# Altium schematic sheet AC-Power-Monitor.SchDoc
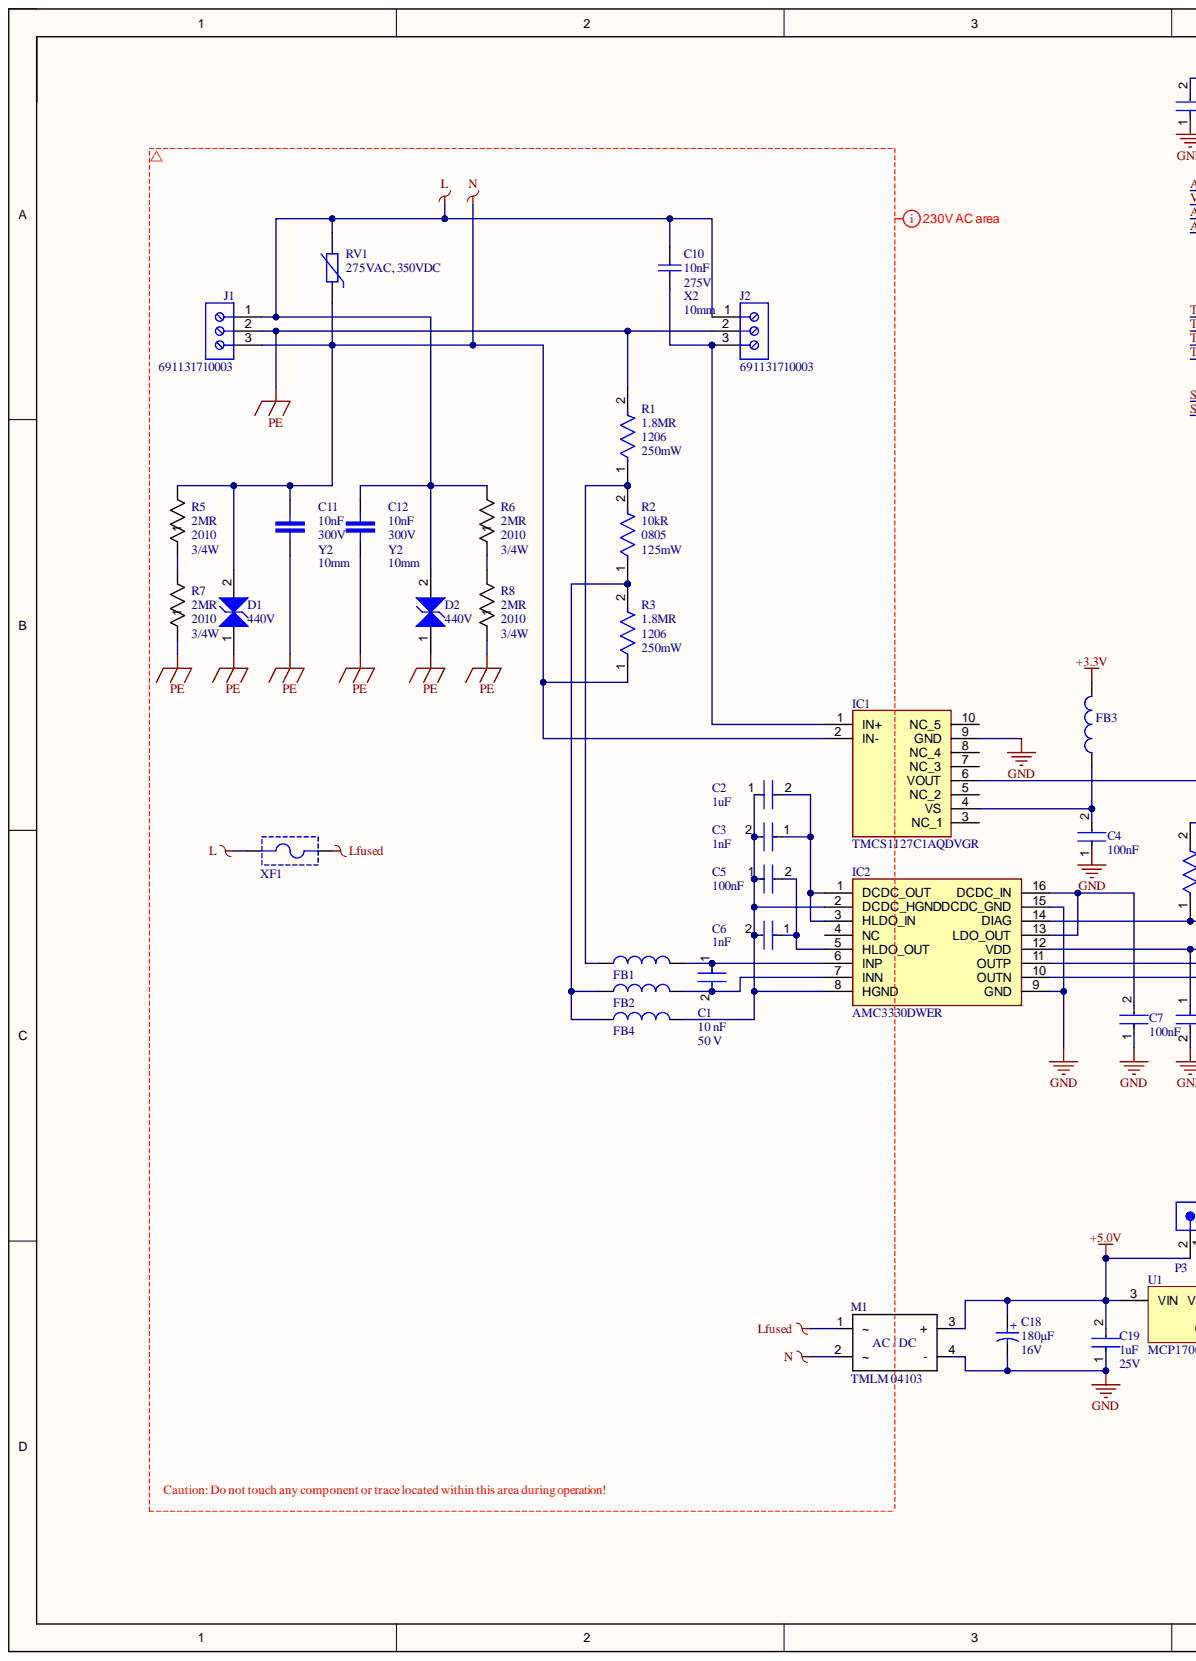
[diagram p0: AC-Power-Monitor.SchDoc, left]
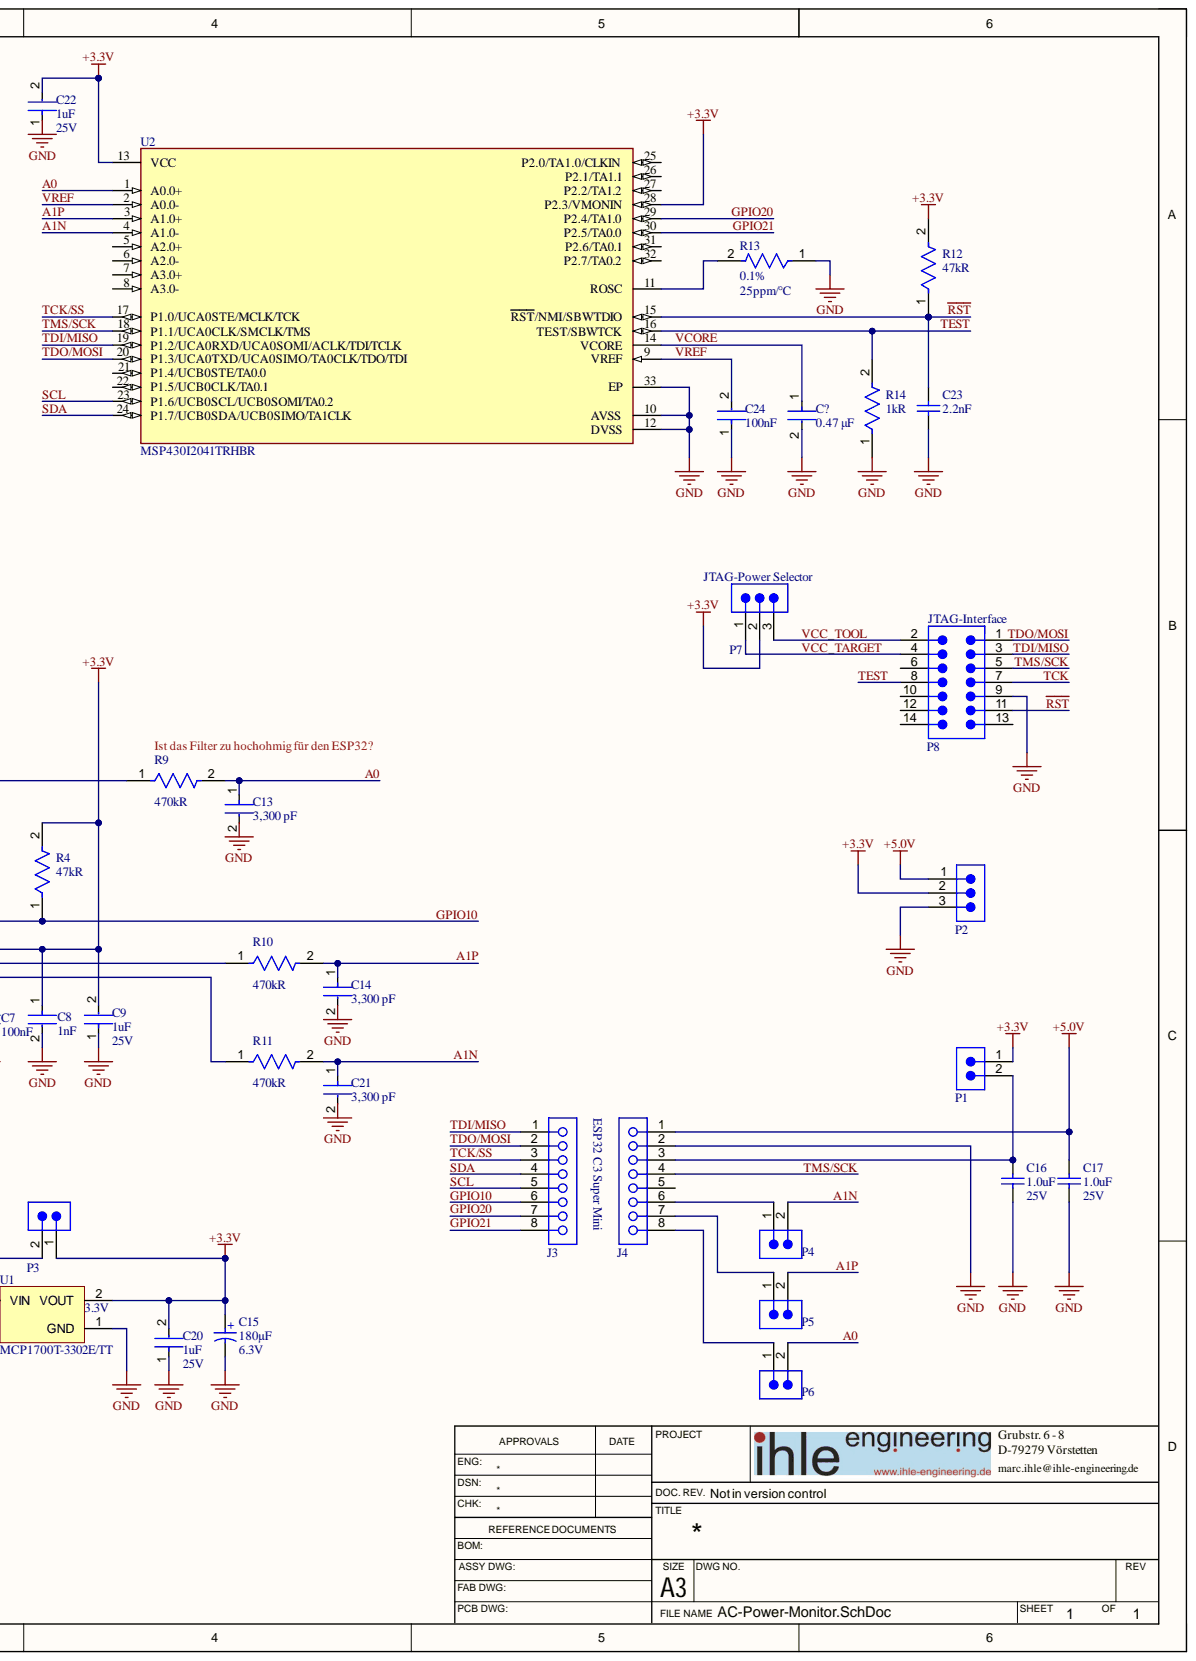
[diagram p1: AC-Power-Monitor.SchDoc, right]

## Parts
C1  value 10 nF  Capacitance: 10 nF  [FP-CC0603-DA-MFG]  (hidden comment: CC0603KRX7R9BB103)
C2  Capacitance: 1uF  [FP-CGA3E-0_3-0_1-IPC_A]  (hidden comment: CGA3E1X7R1E105K080AC)
C3  Capacitance: 1nF  [FP-0603-L_1_6_0_15-W_0_81-IPC_A]  (hidden comment: 06035C102KAT2A)
C4  Capacitance: 100nF  [FP-CGA3E-0_3-0_1-IPC_A]  (hidden comment: CGA3E2X7R1H104K080AA)
C5  Capacitance: 100nF  [FP-CGA3E-0_3-0_1-IPC_A]  (hidden comment: CGA3E2X7R1H104K080AA)
C6  Capacitance: 1nF  [FP-0603-L_1_6_0_15-W_0_81-IPC_A]  (hidden comment: 06035C102KAT2A)
C7  Capacitance: 100nF  [FP-CGA3E-0_3-0_1-IPC_A]  (hidden comment: CGA3E2X7R1H104K080AA)
C8  Capacitance: 1nF  [FP-0603-L_1_6_0_15-W_0_81-IPC_A]  (hidden comment: 06035C102KAT2A)
C9  Capacitance: 1uF  Voltage: 25V  [FP-CGA3E-0_3-0_1-IPC_A]  (hidden comment: CGA3E1X7R1E105K080AC)
C10  10nF  Rated Voltage (AC): 275V  Safty Class: X2  [WCAP-FTX2_L13W5H11_CS]
C11  Capacitance: 10nF  Safty Class: Y2  [MKY22W2]  (hidden comment: MKY22W21003I00)
C12  Capacitance: 10nF  Pitch: 10mm  Safty Class: Y2  Voltage (AC): 300V  [MKY22W2]  (hidden comment: MKY22W21003I00)
C13  value 3,300 pF  Capacitance: 3,300 pF  [FP-C0603C-CF-MFG]  (hidden comment: C0603C332J5GACTU)
C14  value 3,300 pF  Capacitance: 3,300 pF  [FP-C0603C-CF-MFG]  (hidden comment: C0603C332J5GACTU)
C15  180µF  Rated Voltage (DC): 6.3V  [WCAP-PSLC_D8H11.7]
C16  Capacitance: 1.0uF  Rated Voltage: 25V  [CAPC1608X87X35LL15T15]  (hidden comment: C0603C105K3RACTU)
C17  Capacitance: 1.0uF  Rated Voltage: 25V  [CAPC1608X87X35LL15T15]  (hidden comment: C0603C105K3RACTU)
C18  180µF  Rated Voltage (DC): 16V  [WCAP-PSLC_D8H11.7]
C19  Capacitance: 1uF  Voltage: 25V  [FP-CGA3E-0_3-0_1-IPC_A]  (hidden comment: CGA3E1X7R1E105K080AC)
C20  Capacitance: 1uF  Voltage: 25V  [FP-CGA3E-0_3-0_1-IPC_A]  (hidden comment: CGA3E1X7R1E105K080AC)
C21  value 3,300 pF  Capacitance: 3,300 pF  [FP-C0603C-CF-MFG]  (hidden comment: C0603C332J5GACTU)
C22  Capacitance: 1uF  Voltage: 25V  [FP-CGA3E-0_3-0_1-IPC_A]  (hidden comment: CGA3E1X7R1E105K080AC)
C23  Capacitance: 2.2nF  [WCAP-CSGP_0603_L1.6W0.8H0.8_Reflow]  (hidden comment: 885012206085)
C24  Capacitance: 100nF  [FP-CGA3E-0_3-0_1-IPC_A]  (hidden comment: CGA3E2X7R1H104K080AA)
C?  value 0.47 μF  [FP-C0603C-CF-MFG]  (hidden comment: C0603C474K4RACTU)
D1  Operating Voltage (DC): 440V  [WE-TVSP_DO-214AC_Bidirectional]  (hidden comment: 400W, 440VDC)
D2  Operating Voltage (DC): 440V  [WE-TVSP_DO-214AC_Bidirectional]  (hidden comment: 400W, 440VDC)
FB1  1800Ohm  [WE-TMSB_0402_HF/HS/WB]  (value not drawn)
FB2  1800Ohm  [WE-TMSB_0402_HF/HS/WB]  (value not drawn)
FB3  1800Ohm  [WE-TMSB_0402_HF/HS/WB]  (value not drawn)
FB4  1800Ohm  [WE-TMSB_0402_HF/HS/WB]  (value not drawn)
IC1  TMCS1127C1AQDVGR  [TMCS1127C1AQDVGR]
IC2  AMC3330DWER  [SOIC127P1030X265-16N]
J1  691131710003  [691131710003]
J2  691131710003  [691131710003]
J3  61300811821  [61300811821]  (value not drawn)
J4  61300811821  [61300811821]  (value not drawn)
M1  TMLM 04103  [TMPM 04]
P1  61300211121  [61300211121]  (value not drawn)
P2  61300311121  [61300311121]  (value not drawn)
P3  61300211121  [61300211121]  (value not drawn)
P4  61300211121  [61300211121]  (value not drawn)
P5  61300211121  [61300211121]  (value not drawn)
P6  61300211121  [61300211121]  (value not drawn)
P7  61300311121  [61300311121]  (value not drawn)
P8  61301421121  [61301421121]  (value not drawn)
R1  Case Code (Imperial): 1206  Power Rating: 250mW  Resistance: 1.8MR  [FP-RK73H2B-IPC_B]  (hidden comment: RK73H2BTTD1804F)
R2  Case Code (Imperial): 0805  Power Rating: 125mW  Resistance: 10kR  [FP-CRCW0805-e3-IPC_A]  (hidden comment: CRCW080510K0FKEA)
R3  Case Code (Imperial): 1206  Power Rating: 250mW  Resistance: 1.8MR  [FP-RK73H2B-IPC_B]  (hidden comment: RK73H2BTTD1804F)
R4  Resistance: 47kR  [FP-CRCW0603-e3-IPC_B]  (hidden comment: CRCW060347K0FKEA)
R5  Power Rating: 3/4W  Resistance: 2MR  Size: 2010  [RES_RCV2010_VIS]  (hidden comment: RCV20102M00FKEFAT)
R6  Power Rating: 3/4W  Resistance: 2MR  Size: 2010  [RES_RCV2010_VIS]  (hidden comment: RCV20102M00FKEFAT)
R7  Resistance: 2MR  [RES_RCV2010_VIS]  (hidden comment: RCV20102M00FKEFAT)
R8  Power Rating: 3/4W  Resistance: 2MR  Size: 2010  [RES_RCV2010_VIS]  (hidden comment: RCV20102M00FKEFAT)
R9  Resistance: 470kR  [FP-CRCW0603-e3-IPC_A]  (hidden comment: CRCW0603470KFKEA)
R10  Resistance: 470kR  [FP-CRCW0603-e3-IPC_A]  (hidden comment: CRCW0603470KFKEA)
R11  Resistance: 470kR  [FP-CRCW0603-e3-IPC_A]  (hidden comment: CRCW0603470KFKEA)
R12  Resistance: 47kR  [FP-KTR03-IPC_C]  (hidden comment: KTR03EZPF4702)
R13  Temperature Coefficient: 25ppm/°C  Tolerance: 0.1%  [FP-CPF0603-MFG]  (hidden comment: CPF0603B20KE1)
R14  Resistance: 1kR  [FP-AC0603-IPC_B]  (hidden comment: AC0603FR-071KL)
RV1  275VAC, 350VDC  [D16.5W6.7H20P1.3]
U1  MCP1700T-3302E/TT  Output Voltage: 3.3V  [SOT-23-TT3_L]
U2  MSP430I2041TRHBR  [FP-RHB0032M-MFG]
XF1  696106003002  [696106003002]  (value not drawn)

## Nets
+3.3V: C8.1 C9.2 C15.1 C20.2 C22.2 FB3.2 IC2.12(VDD) P1.1 P2.2 P3.1 P7.2 R4.2 R12.2 U1.2 U2.13(VCC) U2.28(P2.3/VMONIN)
+5.0V: C17.2 C18.1 C19.2 J4.1 M1.3(+) P2.1 P3.2 U1.3
GND: C4.1 C7.1 C8.2 C9.1 C13.2 C14.2 C15.2 C16.1 C17.1 C18.2 C19.1 C20.1 C21.2 C22.1 C23.1 C24.1 C?.2 IC1.9(GND) IC2.9(GND) IC2.15(DCDC_GND) J4.2 M1.4(-) P2.3 P8.9 R13.1 R14.1 U1.1 U2.10(AVSS) U2.12(DVSS) U2.33(EP)
L: C10.2 C12.1 D2.2 J1.1 J2.1 R6.2 RV1.2 XF1.1
Lfused: M1.1(~) XF1.2
N: C11.1 D1.2 IC1.2(IN-) J1.3 M1.2(~) R3.1 R5.2 RV1.1
PE: C11.2 C12.2 D1.1 D2.1 J1.2 J2.2 R1.2 R7.1 R8.1
~{RST}: C23.2 P8.11 R12.1 U2.15(RST/NMI/SBWTDIO)
A0: C13.1 P6.2 R9.2 U2.1(A0.0+)
A1N: C21.1 P4.2 R11.2 U2.4(A1.0-)
A1P: C14.1 P5.2 R10.2 U2.3(A1.0+)
GPIO10: IC2.14(DIAG) J3.6 R4.1
GPIO20: J3.7 U2.29(P2.4/TA1.0)
GPIO21: J3.8 U2.30(P2.5/TA0.0)
SCL: J3.5 U2.23(P1.6/UCB0SCL/UCB0SOMI/TA0.2)
SDA: J3.4 U2.24(P1.7/UCB0SDA/UCB0SIMO/TA1CLK)
TCK: P8.7
TCK/SS: J3.3 U2.17(P1.0/UCA0STE/MCLK/TCK)
TDI/MISO: J3.1 P8.3 U2.19(P1.2/UCA0RXD/UCA0SOMI/ACLK/TDI/TCLK)
TDO/MOSI: J3.2 P8.1 U2.20(P1.3/UCA0TXD/UCA0SIMO/TA0CLK/TDO/TDI)
TEST: P8.8 R14.2 U2.16(TEST/SBWTCK)
TMS/SCK: J4.4 P8.5 U2.18(P1.1/UCA0CLK/SMCLK/TMS)
VCC_TARGET: P7.1 P8.4
VCC_TOOL: P7.3 P8.2
VCORE: C?.1 U2.14(VCORE)
VREF: C24.2 U2.2(A0.0-) U2.9(VREF)
NetC1_1: C1.1 FB1.2 IC2.6(INP)
NetC1_2: C1.2 FB2.2 IC2.7(INN)
NetC2_1: C2.1 C3.2 C5.1 C6.2 FB4.2 IC2.2(DCDC_HGND) IC2.8(HGND)
NetC2_2: C2.2 C3.1 IC2.1(DCDC_OUT) IC2.3(HLDO_IN)
NetC4_2: C4.2 FB3.1 IC1.4(VS)
NetC5_2: C5.2 C6.1 IC2.5(HLDO_OUT)
NetC7_2: C7.2 IC2.13(LDO_OUT) IC2.16(DCDC_IN)
NetC10_1: C10.1 IC1.1(IN+) J2.3
NetC16_2: C16.2 J4.3 P1.2
NetFB1_1: FB1.1 R1.1 R2.2
NetFB2_1: FB2.1 FB4.1 R2.1 R3.2
NetIC1_6: IC1.6(VOUT) R9.1
NetIC2_10: IC2.10(OUTN) R11.1
NetIC2_11: IC2.11(OUTP) R10.1
NetJ4_6: J4.6 P4.1
NetJ4_7: J4.7 P5.1
NetJ4_8: J4.8 P6.1
NetR5_1: R5.1 R7.2
NetR6_1: R6.1 R8.2
NetR13_2: R13.2 U2.11(ROSC)

Not connected: IC1 3(NC_1) 5(NC_2) 7(NC_3) 8(NC_4) 10(NC_5); IC2 4(NC); J4 5; P8 6 10 12 13 14; U2 5(A2.0+) 6(A2.0-) 7(A3.0+) 8(A3.0-) 21(P1.4/UCB0STE/TA0.0) 22(P1.5/UCB0CLK/TA0.1) 25(P2.0/TA1.0/CLKIN) 26(P2.1/TA1.1) 27(P2.2/TA1.2) 31(P2.6/TA0.1) 32(P2.7/TA0.2)

## Library data of the parts
C1 = CMP-2100-03600-2: CAP CER 10000PF 50V X7R 0603; Manufacturer: YAGEO; Material: Ceramic; Temperature Coefficient: 15%; Tolerance: 0.1; Automotive: No; Category: Cap; Component Kind: Standard; ComponentLink2Description: Datasheet; ComponentLink2URL: http://www.yageo.com/documents/recent/UPY-GPHC_X7R_6.3V-to-50V_18.pdf; Device Class L1: Passive Components; Device Class L2: Capacitors; Device Class L3: Ceramic Capacitors; Digikey Description: CAP CER 10000PF 50V X7R 0603; Footprint Url: http://www.yageo.com/exep/pages/download/literatures/UPY-C_GEN_24.pdf; Height: 0.9mm; Lead Free: Yes; Library Name: Altium Content Vault; Manufacturer Part Number: CC0603KRX7R9BB103; Mouser Description: Multilayer Ceramic Capacitor, CC Series, 0.01 - F, - 10%, X7R, 50 V, 0603 [1608 Metric]; Octopart: https://octopart.com/cc0603krx7r9bb103-yageo-192938; Package: CC0603-DA; RoHS: TRUE; Temperature: -55°C to +125°C; Temperature Characteristic: X7R; Temperature Range High: +125°C; Temperature Range Low: -55°C; Voltage: 50 V; Voltage Rating: 50 V
C2 C9 C19 C20 C22 = CMP-08246-001507-1: Cap Ceramic 1uF 25V X7R 10% Pad SMD 0603 +125°C Automotive T/R; Case Code (Imperial): 0603; Case Code (Metric): 1608; Case/Package: 0603; Component Kind: Standard; Composition: Ceramic; Depth: 0.8mm; Dielectric: X7R; Height: 0.8mm; Lead Free: Lead Free; Length: 1.6mm; Library Name: Altium Content Vault; Max Operating Temperature: 125°C; Min Operating Temperature: -55°C; Mount: Surface Mount; Package Quantity: 4000; Packaging: Tape and Reel; Ratings: AEC-Q200; REACH SVHC: No SVHC; Termination: SMD/SMT; Thickness: 0.035inch; Tolerance: 10%; Voltage Rating: 25V; Voltage Rating (DC): 25V; Weight: 7.1E-05oz; Width: 0.031inch
  C2: Voltage: 25V
C3 C6 C8 = CMP-2006-04378-2: General Purpose Ceramic Capacitor, 0603, 1nF, 10%, X7R, 15%, 50V; Case Code (Imperial): 0603; Case Code (Metric): 1608; Case/Package: 0603; Component Kind: Standard; Construction: Flat; Contact Plating: Tin; Depth: 0.81mm; Dielectric: X7R; Dielectric Material: Ceramic; Height: 0.9mm; Lead Free: Lead Free; Length: 1.6mm; Library Name: Altium Content Vault; Max Operating Temperature: 125°C; Min Operating Temperature: -55°C; Mount: Surface Mount; Number of Pins: 2; Package Quantity: 4000; Packaging: Tape and Reel; Radiation Hardening: No; REACH SVHC: No SVHC; RoHS Compliant: Yes; Series: -; Termination: SMD/SMT; Thickness: 0.035inch; Tolerance: 10%; Voltage: 50V; Voltage Rating: 50V; Voltage Rating (DC): 50V; Weight: 7.1E-05oz; Width: 0.032inch
C4 C5 C7 C24 = CMP-2000-07546-2: Cap Ceramic 100nF 50V X7R 10% Pad SMD 0603 +125°C Automotive T/R; Case Code (Imperial): 0603; Case Code (Metric): 1608; Case/Package: 0603; Component Kind: Standard; Composition: Ceramic; Depth: 0.8mm; Dielectric: X7R; Height: 0.8mm; Lead Free: Lead Free; Length: 1.6mm; Library Name: Altium Content Vault; Max Operating Temperature: 125°C; Min Operating Temperature: -55°C; Mount: Surface Mount; Package Quantity: 1; Packaging: Tape and Reel; Radiation Hardening: No; Ratings: AEC-Q200; REACH SVHC: No SVHC; Termination: SMD/SMT; Thickness: 0.035inch; Tolerance: 10%; Voltage: 50V; Voltage Rating: 50V; Voltage Rating (DC): 50V; Weight: 7.1E-05oz; Width: 0.8mm
C10 = 890324023006CS: WCAP-FTX2 Film Capacitor, THT, L13 x W5 x H11mm, 10nF, 275V; Manufacturer: Wurth Elektronik; Capacitance Tolerance: +/-10%; Dissipation Factor @1kHz: 0.1%; Dissipation Factor @100kHz: 0.5%; Insulation Resistance: 30GOhm; Pitch: 10mm; Rate of Voltage Rise: 500V/us; Technical Reference: MX2P010103K275ACPP56004; Capacitance: 10nF; Category: Film Capacitors; Component Kind: Standard; ComponentLink1Description: Website Link; ComponentLink1URL: https://www.we-online.com/redexpert/article/890324023006CS?ad; ComponentLink2Description: Datasheet Link; ComponentLink2URL: https://www.we-online.com/redexpert/spec/890324023006CS?ad; Dissipation Factor @10kHz: 0.1%; Height: 11mm; Length: 13mm; Library Name: Altium_WCAP-FTX2 (rev23a).IntLib; Manufacturer Part Number: 890324023006CS; Match Code: WCAP-FTX2; Mount: Through Hole; Operating Temperature Max: 105°C; Operating Temperature Min: -40°C; Packaging: Carton; Simulation: 890324023006CS_10nF; Width: 5mm
C11 = C_unipolar: Pitch: 10mm; Voltage (AC): 300V; Component Kind: Standard; Library Name: Ihle_Components.IntLib
C12 = C_unipolar: Component Kind: Standard; Library Name: Ihle_Components.IntLib
C13 C14 C21 = CMP-2006-03334-2: CAP CER 3300PF 50V 5% NP0 0603; Manufacturer: KEMET; Automotive Grade: Grade 1; Material: Ceramic; Temperature Characteristic: C0G; Temperature Coefficient: 30ppm/°C; Tolerance: 0.05; Automotive: Yes; Category: Cap; Component Kind: Standard; ComponentLink2Description: Datasheet; ComponentLink2URL: https://api.kemet.com/component-edge/download/datasheet/C0603C100J5GACAUTO.pdf; Device Class L1: Passive Components; Device Class L2: Capacitors; Device Class L3: Ceramic Capacitors; Digikey Description: CAP CER 3300PF 50V 5% NP0 0603; Height: 0.87mm; Lead Free: Yes; Library Name: Altium Content Vault; Manufacturer Part Number: C0603C332J5GACTU; Mouser Description: Multilayer Ceramic Capacitors MLCC - SMD/SMT 50volts 3300pF 5% C0G; Octopart: https://octopart.com/c0603c332j5gactu-kemet-12580539; Package: C0603C-CF; RoHS: TRUE; Temperature: -55°C to +125°C; Temperature Range High: +125°C; Temperature Range Low: -55°C; Voltage Rating: 50 V
C15 = 875075155001: WCAP-PSLC Aluminum Polymer Capacitor, V-Chip SMT, D8 x H11.7mm, 180µF, 6.3V; Manufacturer: Wurth Elektronik; Capacitance Tolerance: +/-20%; Dissipation Factor: 8%; Endurance: 2000h; ESR: 8mΩ; Leakage Current: 226.8µA; Ripple Current: 5200mA; Technical Reference: PSEE117181M6R3DVCTFB000; Capacitance: 180µF; Category: Aluminum Polymer Capacitors; Component Kind: Standard; ComponentLink1Description: Website Link; ComponentLink1URL: https://www.we-online.com/redexpert/article/875075155001?ad; ComponentLink2Description: Datasheet Link; ComponentLink2URL: https://www.we-online.com/redexpert/spec/875075155001?ad; Diameter: 8mm; Height: 11.7mm; Library Name: Altium_WCAP-PSLC (rev22c)(1).IntLib; Manufacturer Part Number: 875075155001; Match Code: WCAP-PSLC; Mount: Surface Mount; Operating Temperature Max: 105°C; Operating Temperature Min: -55°C; Packaging: Tape and Reel; Simulation: 875075155001_180uF
C16 C17 = CMP-2006-03713-1: Manufacturer: Kemet; Component Kind: Standard; Library Name: Altium Content Vault; Part Number: C0603C105K3RACTU
C18 = 875075355001: WCAP-PSLC Aluminum Polymer Capacitor, V-Chip SMT, D8 x H11.7mm, 180µF, 16V; Manufacturer: Wurth Elektronik; Capacitance Tolerance: +/-20%; Dissipation Factor: 8%; Endurance: 2000h; ESR: 10mΩ; Leakage Current: 576µA; Ripple Current: 4700mA; Technical Reference: PSEE117181M016DVCTFB000; Capacitance: 180µF; Category: Aluminum Polymer Capacitors; Component Kind: Standard; ComponentLink1Description: Website Link; ComponentLink1URL: https://www.we-online.com/redexpert/article/875075355001?ad; ComponentLink2Description: Datasheet Link; ComponentLink2URL: https://www.we-online.com/redexpert/spec/875075355001?ad; Diameter: 8mm; Height: 11.7mm; Library Name: Altium_WCAP-PSLC (rev22c)(1).IntLib; Manufacturer Part Number: 875075355001; Match Code: WCAP-PSLC; Mount: Surface Mount; Operating Temperature Max: 105°C; Operating Temperature Min: -55°C; Packaging: Tape and Reel; Simulation: 875075355001_180uF
C23 = CMP-03913-53914794-1: 885012206085 Multilayer Ceramic Capacitor, Wcap-Csgp Series, 2200 Pf, 10%, X7R, 50 V, 0603 [1608 Metric] Rohs Compliant: Yes...; Insulation Resistance: 10GΩ; Voltage Rating: 50V; Case Code (Imperial): 0603; Case Code (Metric): 1608; Case/Package: 0603; Component Kind: Standard; Dielectric: X7R; Height: 800µm; Length: 1.6mm; Library Name: Altium Content Vault; Max Operating Temperature: 125°C; Min Operating Temperature: -55°C; Mount: Surface Mount; NexarPartId: 53914794; Packaging: Cut Tape (CT); REACH SVHC: Unknown; RoHS: Compliant; Thickness: 939.8µm; Tolerance: 10%; Voltage Rating (DC): 50V; Width: 800µm
C? = CMP-2006-03235-2: C0603 0.47 μF X7R 30ppm/°C 10.00% 16 V; Manufacturer: KEMET; Automotive Grade: Grade 1; Capacitance: 0.47 μF; Material: Ceramic; Tolerance: 0.1; Value: 0.47 μF; Voltage Rating: 16 V; Automotive: Yes; Category: Cap; Component Kind: Standard; ComponentLink2Description: Datasheet; ComponentLink2URL: https://api.kemet.com/component-edge/download/datasheet/C0603C100J5GACAUTO.pdf; Device Class L1: Passive Components; Device Class L2: Capacitors; Device Class L3: Ceramic Capacitors; Digikey Description: CAP CER 0.47UF 16V X7R 0603; Height: 0.87mm; Lead Free: Yes; Library Name: Altium Content Vault; Manufacturer Part Number: C0603C474K4RACTU; Mouser Description: 0603 C 470nF Ceramic Multilayer Capacitor, 16 V, +125degC, X7R Dielec, +/-10%; Octopart: https://octopart.com/c0603c474k4ractu-kemet-109683; Package: C0603C-CF; RoHS: TRUE; Temperature: -55°C to +125°C; Temperature Characteristic: X7R; Temperature Coefficient: 30ppm/°C; Temperature Range High: +125°C; Temperature Range Low: -55°C
D1 D2 = 824501442: WE-TVSP SMT Power TVS Diode, DO-214AC, Bidirectional, 400W, 440VDC; Manufacturer: Wurth Elektronik; (Reverse) Breakdown Voltage: 517.5V; (Reverse) Peak Pulse Current: 0.6A; Clamping Voltage: 713V; Case/Size Code: DO-214AC; Category: Surge Protection; Component Kind: Standard; ComponentLink1Description: Website Link; ComponentLink1URL: https://www.we-online.com/redexpert/article/824501442?ad; ComponentLink2Description: Datasheet Link; ComponentLink2URL: https://www.we-online.com/redexpert/spec/824501442?ad; Height: 2.25mm; Length: 4.24mm; Library Name: Altium_WE-TVSP (rev22b)(1).IntLib; Manufacturer Part Number: 824501442; Match Code: WE-TVSP; Mount: Surface Mount; Operating Temperature Max: 150°C; Operating Temperature Min: -65°C; Packaging: Tape and Reel; Polarity: Bidirectional; Power Dissipation: 400W; Width: 2.67mm
FB1-FB4 = 74269244182: WE-TMSB Tiny Multilayer Suppression Bead, size 0402, 1800Ohm, 0.21A; Manufacturer: Wurth Elektronik; Case/Size Code: 0402; DC Resistance: 1910mOhm; Impedance @1GHz: 1500Ohm; Impedance Max: 2700Ohm; Impedance Max Test Condition: 450MHz; Type: High Frequency; Category: Ferrites for PCB Assembly; Component Kind: Standard; ComponentLink1Description: Website Link; ComponentLink1URL: https://www.we-online.com/redexpert/article/74269244182?ad; ComponentLink2Description: Datasheet Link; ComponentLink2URL: https://www.we-online.com/redexpert/spec/74269244182?ad; Height: 0.5mm; Impedance @100MHz: 1800Ohm; Length: 1mm; Library Name: WE-TMSB (rev24a).IntLib; Manufacturer Part Number: 74269244182; Match Code: WE-TMSB; Mount: Surface Mount; Operating Temperature Max: 125°C; Operating Temperature Min: -55°C; Packaging: Tape and Reel; Rated Current: 0.21A; Simulation: 0402_74269244182_1800ohm; Width: 0.5mm
IC1 = TMCS1127C1AQDVGR: Integrated Circuit; Manufacturer_Name: Texas Instruments; Arrow Part Number: TMCS1127C1AQDVGR; Arrow Price/Stock: https://www.arrow.com/en/products/tmcs1127c1aqdvgr/texas-instruments?region=nac; Component Kind: Standard; Datasheet Link: https://www.ti.com/lit/ds/symlink/tmcs1127.pdf?ts=1735303481537&ref_url=https%253A%252F%252Fwww.ti.com%252Fproduct%252FTMCS1127%252Fpart-details%252FTMCS1127C1AQDVGR; Height: 2.65mm; Manufacturer_Part_Number: TMCS1127C1AQDVGR
IC2 = AMC3330DWER: Integrated Circuit; Manufacturer_Name: Texas Instruments; Arrow Part Number: AMC3330DWER; Arrow Price/Stock: https://www.arrow.com/en/products/amc3330dwer/texas-instruments?utm_currency=USD&region=nac; Component Kind: Standard; Datasheet Link: https://www.ti.com/lit/ds/symlink/amc3330.pdf?ts=1627904955935&ref_url=https%253A%252F%252Fwww.ti.com%252Fproduct%252FAMC3330; Height: 2.65mm; Manufacturer_Part_Number: AMC3330DWER; Mouser Part Number: 595-AMC3330DWER; Mouser Price/Stock: https://www.mouser.co.uk/ProductDetail/Texas-Instruments/AMC3330DWER?qs=zW32dvEIR3u5qLqnY17MoA%3D%3D
J1 J2 = 691131710003: WR-TBL Series 1317 Horizontal Entry, THT, pitch 5mm, 03p; Manufacturer: Wurth Elektronik; Modularity: No; Rated Current: 16A; System: Wire Protector; Working Voltage: 300V; Application: Pressure Clamp Divisible; Category: Terminal Block; Component Kind: Standard; ComponentLink1Description: Website Link; ComponentLink1URL: https://www.we-online.com/redexpert/article/691131710003?ad; ComponentLink2Description: Datasheet Link; ComponentLink2URL: https://www.we-online.com/redexpert/spec/691131710003?ad; Library Name: Altium_WR-TBL_Wire Protector (rev25a).IntLib; Manufacturer Part Number: 691131710003; Match Code: WR-TBL; Max Operating Temperature: 120°C; Min Operating Temperature: -30°C; Mount: Through Hole; Number of Pins: 3; Number of Rows: 1; Orientation: Horizontal; Packaging: Box; Pitch: 5mm
J3 J4 = 61300811821: WR-PHD Socket Header, THT, Vertical, pitch 2.54mm, 1 Row, 8P; Manufacturer: Wurth Elektronik; Contact Resistance: 20mOhm; Rated Current: 3A; Withstanding Voltage: 500V; Working Voltage: 250V; Category: Board-to-Board Connectors; Component Kind: Standard; ComponentLink1Description: Website Link; ComponentLink1URL: https://www.we-online.com/redexpert/article/61300811821?ad; ComponentLink2Description: Datasheet Link; ComponentLink2URL: https://www.we-online.com/redexpert/spec/61300811821?ad; Gender: Socket Header; Library Name: Altium_WR-PHD (rev24a).IntLib; Manufacturer Part Number: 61300811821; Match Code: WR-PHD; Mount: Through Hole; Number of Pins: 8; Number of Rows: 1; Operating Temperature Max: 125°C; Operating Temperature Min: -40°C; Orientation: Vertical; Packaging: Tray; Pitch: 2.54mm
M1 = AC/DC-Buck-Module: Component Kind: Standard; Library Name: Ihle_Components.IntLib
P1 P3-P6 = 61300211121: WR-PHD Pin Header, THT, Vertical, pitch 2.54mm, 1 Row, 2P; Manufacturer: Wurth Elektronik; Contact Resistance: 20mOhm; Rated Current: 3A; Withstanding Voltage: 500V; Working Voltage: 250V; Category: Board-to-Board Connectors; Component Kind: Standard; ComponentLink1Description: Website Link; ComponentLink1URL: https://www.we-online.com/redexpert/article/61300211121?ad; ComponentLink2Description: Datasheet Link; ComponentLink2URL: https://www.we-online.com/redexpert/spec/61300211121?ad; Gender: Pin Header; Library Name: Altium_WR-PHD (rev24a).IntLib; Manufacturer Part Number: 61300211121; Match Code: WR-PHD; Mount: Through Hole; Number of Pins: 2; Number of Rows: 1; Operating Temperature Max: 105°C; Operating Temperature Min: -40°C; Orientation: Vertical; Packaging: Bag; Pitch: 2.54mm
P2 P7 = 61300311121: WR-PHD Pin Header, THT, Vertical, pitch 2.54mm, 1 Row, 3P; Manufacturer: Wurth Elektronik; Contact Resistance: 20mOhm; Rated Current: 3A; Withstanding Voltage: 500V; Working Voltage: 250V; Category: Board-to-Board Connectors; Component Kind: Standard; ComponentLink1Description: Website Link; ComponentLink1URL: https://www.we-online.com/redexpert/article/61300311121?ad; ComponentLink2Description: Datasheet Link; ComponentLink2URL: https://www.we-online.com/redexpert/spec/61300311121?ad; Gender: Pin Header; Library Name: Altium_WR-PHD (rev24a).IntLib; Manufacturer Part Number: 61300311121; Match Code: WR-PHD; Mount: Through Hole; Number of Pins: 3; Number of Rows: 1; Operating Temperature Max: 105°C; Operating Temperature Min: -40°C; Orientation: Vertical; Packaging: Bag; Pitch: 2.54mm
P8 = 61301421121: WR-PHD Pin Header, THT, Vertical, pitch 2.54mm, 2 Row, 14P; Manufacturer: Wurth Elektronik; Contact Resistance: 20mOhm; Rated Current: 3A; Withstanding Voltage: 500V; Working Voltage: 250V; Category: Board-to-Board Connectors; Component Kind: Standard; ComponentLink1Description: Website Link; ComponentLink1URL: https://www.we-online.com/redexpert/article/61301421121?ad; ComponentLink2Description: Datasheet Link; ComponentLink2URL: https://www.we-online.com/redexpert/spec/61301421121?ad; Gender: Pin Header; Library Name: Altium_WR-PHD (rev24a).IntLib; Manufacturer Part Number: 61301421121; Match Code: WR-PHD; Mount: Through Hole; Number of Pins: 14; Number of Rows: 2; Operating Temperature Max: 105°C; Operating Temperature Min: -40°C; Orientation: Vertical; Packaging: Bag; Pitch: 2.54mm
R1 R3 = CMP-2003-01283-2: 1.8MΩ ±1% 0.25W Chip Resistor 1206 Thick Film AEC-Q200 Qualified; Temperature Coefficient: 200ppm/°C; Voltage Rating: 200V; Case Code (Metric): 3216; Case/Package: 1206; Component Kind: Standard; Lead Free: Lead Free; Library Name: Altium Content Vault; Number of Pins: 2; Resistance Tolerance: 1%; Resistor Type: Thick Film; RoHS Compliant: Yes; Series: RK73H; Tolerance: 1%
R2 = CMP-2001-04908-2: RES Thick Film, 10kΩ, 1%, 0.125W, 100ppm/°C, 0805; Voltage Rating: 150V; Case Code (Metric): 2012; Case/Package: 0805; Component Kind: Standard; Composition: Thick Film; Contact Plating: Tin; Depth: 1.25mm; Height: 0.45mm; Lead Free: Lead Free; Length: 2mm; Library Name: Altium Content Vault; Max Operating Temperature: 155°C; Military Standard: Not; Min Operating Temperature: -55°C; Package Quantity: 5000; Packaging: Tape and Reel; Radiation Hardening: No; Temperature Coefficient: 100ppm/°C; Termination: SMD/SMT; Tolerance: 1%; Voltage Rating (DC): 150V; Weight: 0.000194oz; Width: 1.25mm
R4 = CMP-2000-03379-3: RES SMD 47K OHM 1% 1/10W 0603; Max Power Dissipation: 100mW; Temperature Coefficient: 100ppm/°C; Voltage Rating: 75V; Case Code (Imperial): 0603; Case Code (Metric): 1608; Case/Package: 0603; Component Kind: Standard; Construction: Rectangular; Contact Plating: Tin; Depth: 0.85mm; Features: Automotive AEC-Q200; Height: 0.45mm; Lead Free: Lead Free; Length: 1.55mm; Library Name: Altium Content Vault; Max Operating Temperature: 155°C; Military Standard: Not; Min Operating Temperature: -55°C; Mount: Surface Mount; Number of Terminations: 2; Package Quantity: 5000; Packaging: Tape and Reel; Power Rating: 100mW; Radiation Hardening: No; Resistance Tolerance: 1%; Resistor Type: Thick Film; RoHS Compliant: Yes; Series: CRCW; Size Code: 0603; Technology: THICK FILM; Termination: SMD/SMT; Tolerance: 1%; Voltage Rating (DC): 75V; Weight: 7.1E-05oz; Width: 0.85mm
R5-R8 = CMP-00030-112021045-1: RCV20102M00FKEFAT RES 2M OHM 1% 3/4W 2010 anti surge high power; Component Kind: Standard; Library Name: Altium Content Vault; NexarPartId: 112021045
  R7: Power Rating: 3/4W; Size: 2010
R9-R11 = CMP-2000-03370-2: RES Thick Film, 470kΩ, 1%, 0.1W, 100ppm/°C, 0603; Max Power Dissipation: 100mW; Voltage Rating: 75V; Case Code (Imperial): 0603; Case Code (Metric): 1608; Case/Package: 0603; Component Kind: Standard; Composition: Thick Film; Contact Plating: Tin; Depth: 0.85mm; Features: Automotive AEC-Q200; Height: 0.45mm; Lead Free: Lead Free; Length: 1.55mm; Library Name: Altium Content Vault; Max Operating Temperature: 155°C; Military Standard: Not; Min Operating Temperature: -55°C; Mount: Surface Mount; Number of Pins: 2; Number of Terminations: 2; Package Quantity: 10; Packaging: Tape and Reel; Power Rating: 100mW; Radiation Hardening: No; Temperature Coefficient: 100ppm/°C; Termination: SMD/SMT; Tolerance: 1%; Voltage Rating (DC): 75V; Weight: 7.1E-05oz; Width: 0.033inch
R12 = CMP-08839-030818-1: Res Thick Film 0603 47kΩ 1% 0.1W ±100ppm/°C Automotive Paper T/R; Max Power Dissipation: 100mW; Case Code (Imperial): 0603; Case Code (Metric): 1608; Case/Package: 0603; Component Kind: Standard; Features: Automotive AEC-Q200; Height: 0.022inch; Length: 0.063inch; Library Name: Altium Content Vault; Max Operating Temperature: 155°C; Min Operating Temperature: -55°C; Number of Terminations: 2; Package Quantity: 1; Packaging: Tape and Reel; Resistor Type: Thick Film; Series: KTR; Temperature Coefficient: 100ppm/°C; Tolerance: 1%; Width: 0.031inch
R13 = CMP-03211-006634-1: RES SMD 20KΩ 0.1% 1/16W 0603; Manufacturer: TE Connectivity; Power Rating: 0.063W; Voltage Rating: 50V; Automotive: No; Category: Res; Component Kind: Standard; ComponentLink2Description: Datasheet; ComponentLink2URL: https://www.te.com/commerce/DocumentDelivery/DDEController?Action=showdoc&DocId=Data+Sheet%7F1773200%7FM%7Fpdf%7FEnglish%7FENG_DS_1773200_M.pdf%7F3-1676481-2; Composition: Thin Film; Device Class L1: Passive Components; Device Class L2: Resistors; Device Class L3: Chip SMD Resistors; Height: 0.55mm; Ipc Land Pattern Name: RESC15580X45; Lead Free: Yes; Library Name: Altium Content Vault; Manufacturer Part Number: CPF0603B20KE1; Octopart: https://octopart.com/cpf0603b20ke1-te+connectivity-22738773; octopart_url: https://octopart.com/cpf0603b20ke1-te+connectivity-22738773; Package: CPF0603; packaging: 1k Reel; Resistance: 20KΩ; RoHS: TRUE; Series: CPF; Temperature: -55°C to +155°C; Temperature Range High: +155°C; Temperature Range Low: -55°C; Value: 20KΩ
R14 = CMP-2000-04828-3: RES 1kΩ 1.00% 0.1W 0603; Max Power Dissipation: 100mW; Temperature Coefficient: 100ppm/°C; Tolerance: 1%; Voltage Rating: 50V; Case Code (Imperial): 0603; Case Code (Metric): 1608; Case/Package: 0603; Component Kind: Standard; Construction: Rectangular; Depth: 0.8mm; Features: Moisture Resistant; Height: 0.022inch; Lead Free: Lead Free; Length: 0.063inch; Library Name: Altium Content Vault; Max Operating Temperature: 155°C; Military Standard: Not; Min Operating Temperature: -55°C; Number of Terminations: 2; Package Quantity: 1; Packaging: Tape and Reel; Power Rating: 100mW; Ratings: AEC-Q200; Resistor Type: Thick Film; RoHS Compliant: Yes; Series: AC; Size Code: 0603; Termination: SMD/SMT; Width: 0.031inch
RV1 = 820442711E: WE-VD Disk Varistor, THT, 275VAC, 350VDC, 450pF; Manufacturer: Wurth Elektronik; (Reverse) Breakdown Voltage: 430V; (Reverse) Peak Pulse Current: 6000A; Clamping Voltage: 710V; Energy Absorption: 155J; Operating Voltage (AC): 275V; Operating Voltage (DC): 350V; Pitch: 1.3mm; Power Dissipation: 0.6W; Category: Surge Protection; Channel Input Capacitance: 450pF; Component Kind: Standard; ComponentLink1Description: Website Link; ComponentLink1URL: https://www.we-online.com/redexpert/article/820442711E?ad; ComponentLink2Description: Datasheet Link; ComponentLink2URL: https://www.we-online.com/redexpert/spec/820442711E?ad; Diameter: 16.5mm; Height: 20mm; Library Name: Altium_WE-VD (rev23a)(1).IntLib; Manufacturer Part Number: 820442711E; Match Code: WE-VD; Mount: Through Hole; Operating Temperature Max: 85°C; Operating Temperature Min: -40°C; Packaging: Tape and Reel; Width: 6.7mm
U1 = CMP-0136-00788-1: Low Quiescent Current LDO, 3-Pin SOT-23, Extended Temperature, Tape and Reel; Code_IPC: SOT23-3; Component Kind: Standard; DatasheetVersion: revB, Feb-2007; Library Name: Altium Content Vault; PackageDescription: 3-Lead Plastic Small Outline Transistor (TT) [SOT-23]; PackageReference: SOT-23-TT3; PackageVersion: revBB, Aug-2009
U2 = CMP-04869-000074-1: IC MCU 16BIT 32KB FLASH 32VQFN; Core Architecture: MSP430; Data Bus Width: 16b; Frequency: 16.384MHz; Interface: I2C; Max Supply Voltage: 3.6V; Min Supply Voltage: 2.2V; Number of ADC Channels: 4; Number of I/Os: 16; Number of Timers/Counters: 2; Number of UART Channels: 1; Oscillator Type: External; Peripherals: Brown-out Detect/Reset; RAM Size: 2KB; Watchdog Timer: Yes; Case/Package: VQFN; Component Kind: Standard; Contact Plating: Gold; Lead Free: Lead Free; Library Name: Altium Content Vault; Max Frequency: 16.384MHz; Max Operating Temperature: 105°C; Memory Size: 32KB; Memory Type: FLASH; Min Operating Temperature: -40°C; Mount: Surface Mount; Number of Pins: 32; Number of Programmable I/O: 16; Package Quantity: 3000; Packaging: Tape and Reel; Radiation Hardening: No; RoHS Compliant: Yes; Weight: 0.002547oz
XF1 = 696106003002: WR-FSH Fuseholder THT Blocks PCB Clip Cover; Manufacturer: Wurth Elektronik; Fuse Size: 5x20mm; Category: Fuse Holder; Component Kind: Standard; ComponentLink1Description: Website Link; ComponentLink1URL: https://www.we-online.com/redexpert/article/696106003002?ad; ComponentLink2Description: Datasheet Link; ComponentLink2URL: https://www.we-online.com/redexpert/spec/696106003002?ad; Library Name: Altium_WR-FSH (rev22a)(1).IntLib; Manufacturer Part Number: 696106003002; Match Code: WR-FSH; Mount: THT; Operating Temperature Max: 120°C; Operating Temperature Min: -30°C; Packaging: Box
